# Altium schematic sheet IsoCore_Main.SchDoc
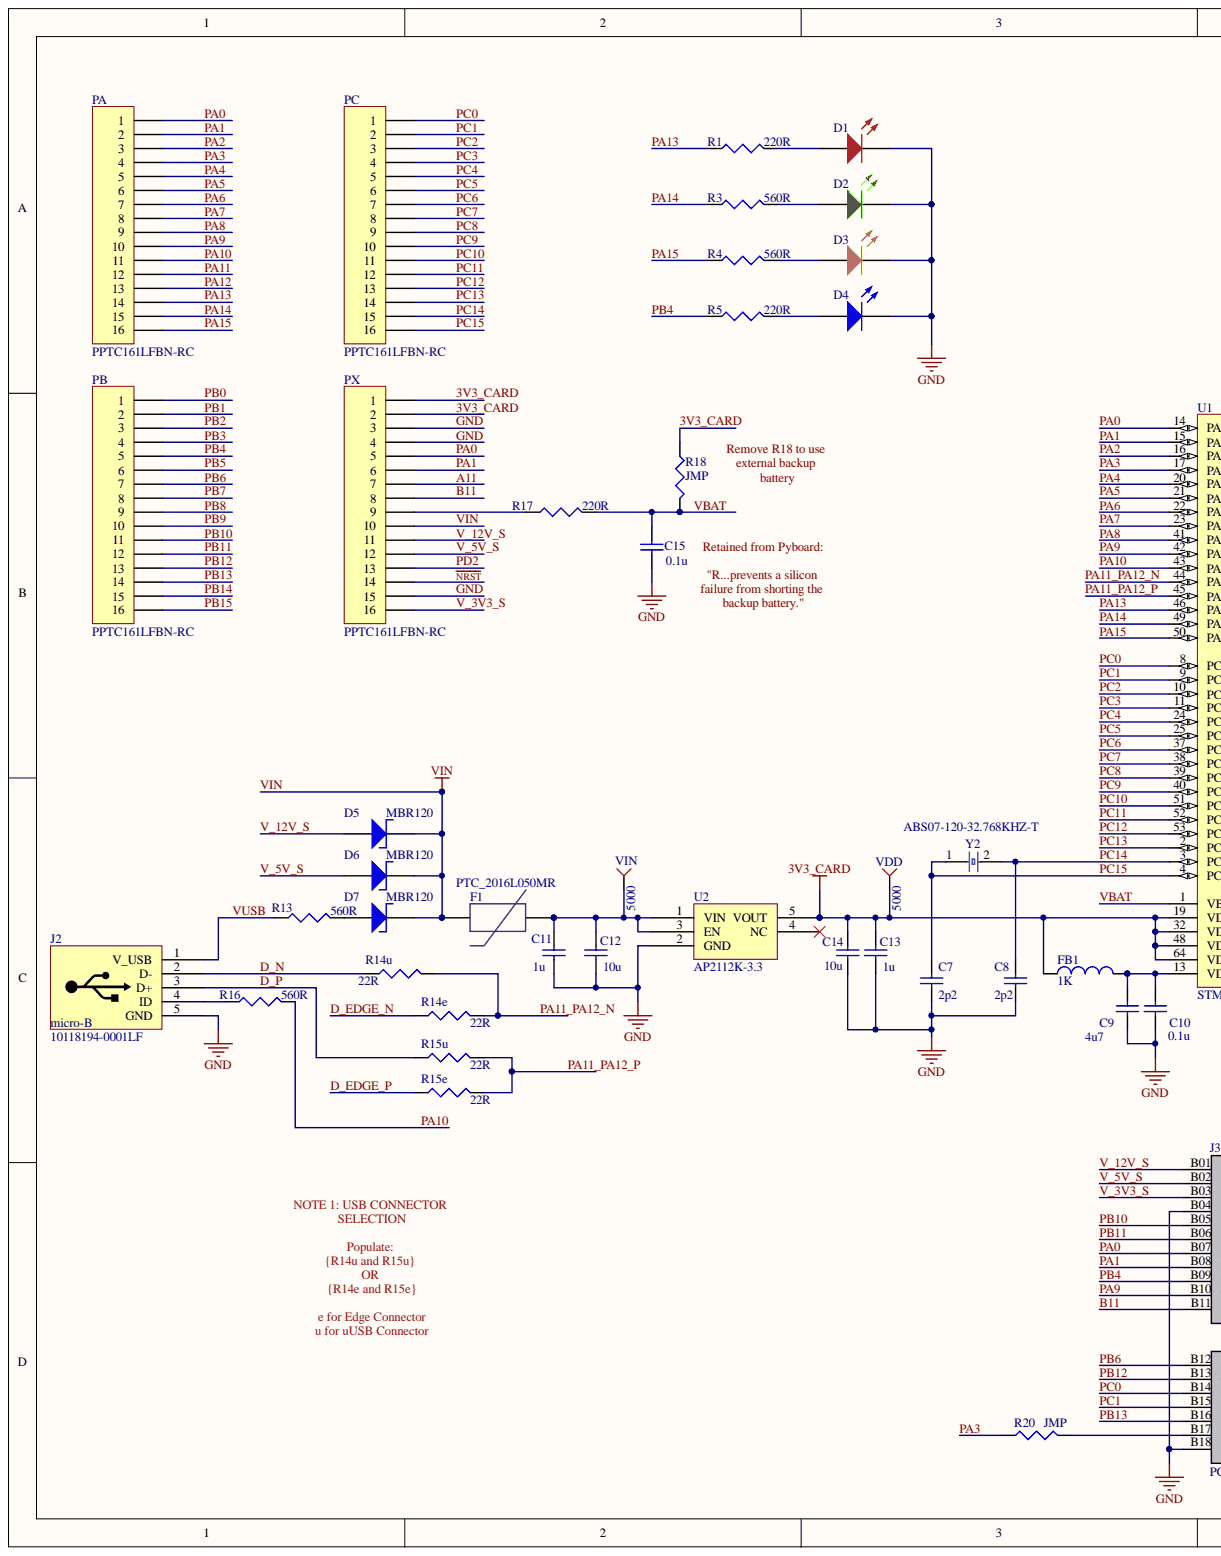
[diagram p0: IsoCore_Main.SchDoc, left]
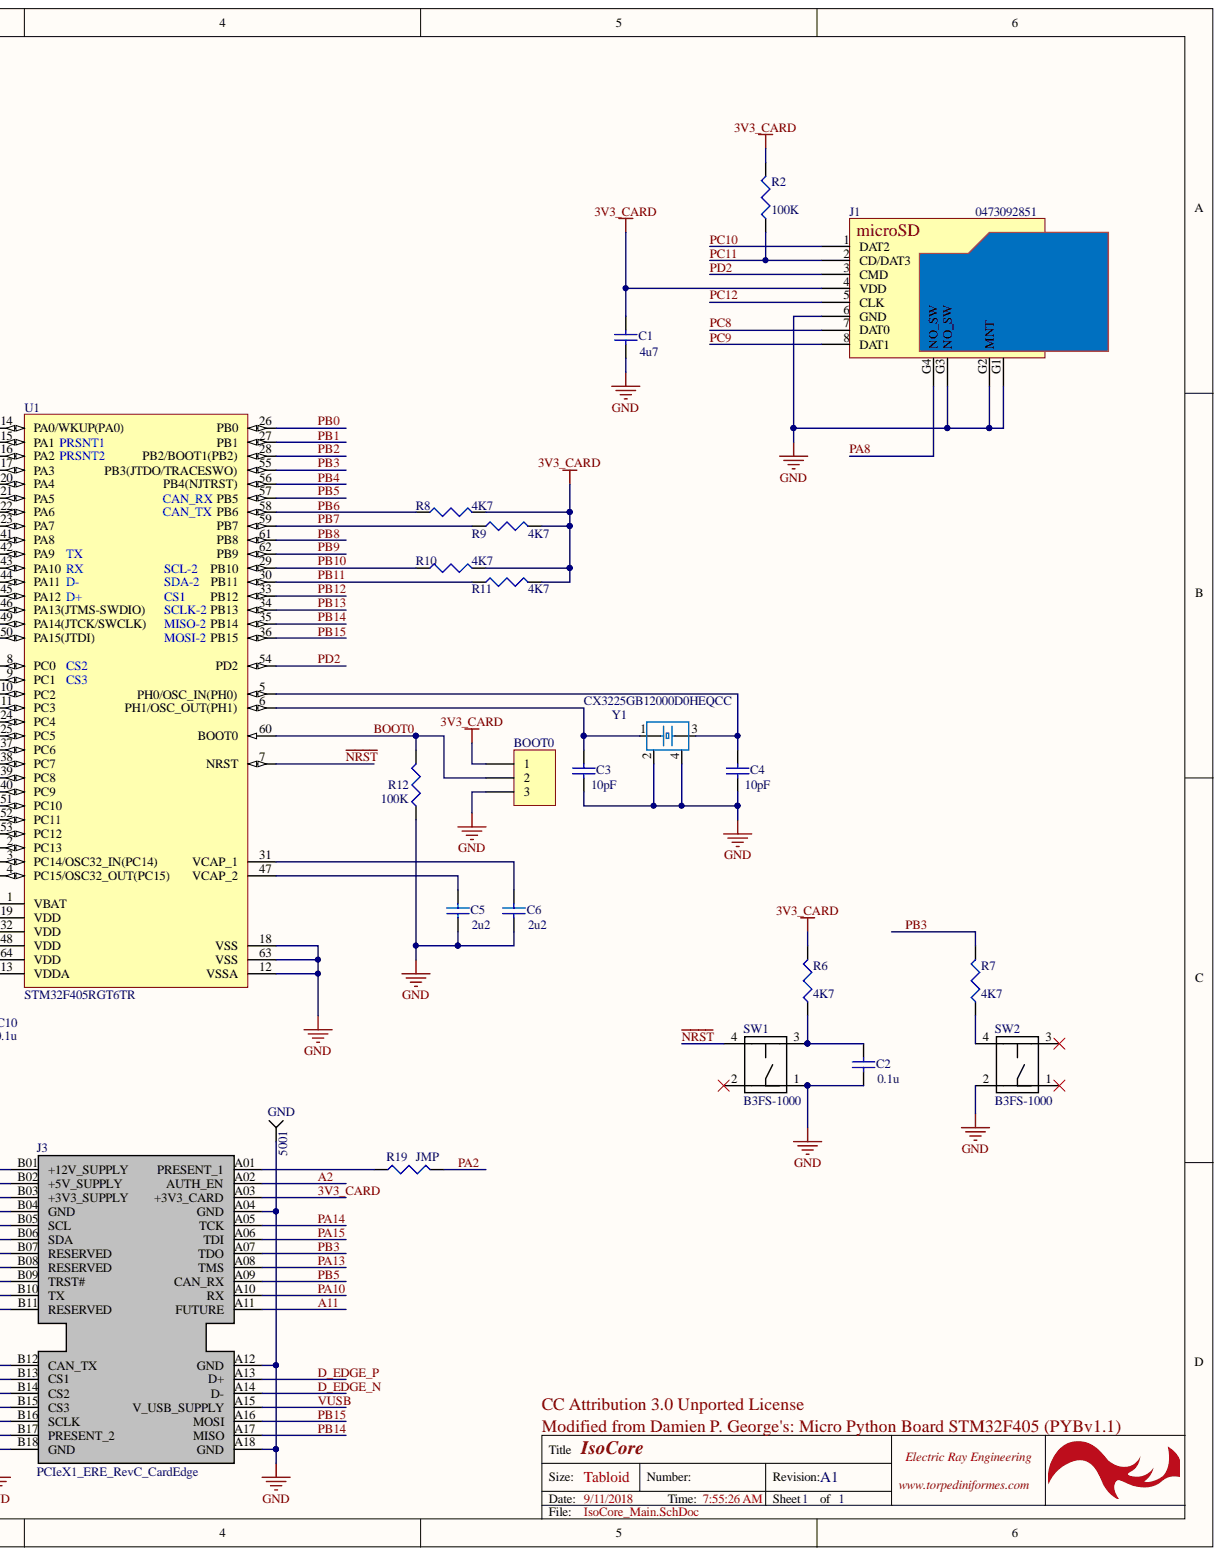
[diagram p1: IsoCore_Main.SchDoc, right]

## Parts
BOOT0  Header 3  [HDR1X3]  (value not drawn)
C1  value 4u7  [1608[0603]]  (hidden comment: Cap Semi)
C2  value 0.1u  [1608[0603]]  (hidden comment: Cap Semi)
C3  value 10pF  [1608[0603]]  (hidden comment: Cap Semi)
C4  value 10pF  [1608[0603]]  (hidden comment: Cap Semi)
C5  value 2u2  [1608[0603]]  (hidden comment: Cap Semi)
C6  value 2u2  [1608[0603]]  (hidden comment: Cap Semi)
C7  value 2p2  [1608[0603]]  (hidden comment: Cap Semi)
C8  value 2p2  [1608[0603]]  (hidden comment: Cap Semi)
C9  value 4u7  [1608[0603]]  (hidden comment: Cap Semi)
C10  value 0.1u  [1608[0603]]  (hidden comment: Cap Semi)
C11  value 1u  [1608[0603]]  (hidden comment: Cap Semi)
C12  value 10u  [C1206]  (hidden comment: Cap Semi)
C13  value 1u  [1608[0603]]  (hidden comment: Cap Semi)
C14  value 10u  [C1206]  (hidden comment: Cap Semi)
C15  value 0.1u  [1608[0603]]  (hidden comment: Cap Semi)
D1  LED_RED_SMD  [IN-S63AT_RED]  (value not drawn)
D2  LED_GREEN_0603  [IN-S63AT_GRN]  (value not drawn)
D3  LED_ORANGE_0603  [LO Q976]  (value not drawn)
D4  LED_BLUE_0603  [IN-S63AT_BLU]  (value not drawn)
D5  Diode  [SOD-123FL]  (value not drawn)
D6  Diode  [SOD-123FL]  (value not drawn)
D7  Diode  [SOD-123FL]  (value not drawn)
F1  PTC_2016L050MR  [PolyFuse_2016L]
FB1  value 1K  [J1-0603]  (hidden comment: Inductor)
GND  Manufacturer Part Number: 5001  [BLK_TP_KEYSTONE]  (hidden comment: BLK_TESTPOINT)
J1  0473092851  [0473092851]
J2  10118194-0001LF  [USB-R/A-10118194-0001LF]
J3  PCIeX1_ERE_RevC_CardEdge  [PCIeX1]
PA  PPTC161LFBN-RC  [1x16 100mil F Header]
PB  PPTC161LFBN-RC  [1x16 100mil F Header]
PC  PPTC161LFBN-RC  [1x16 100mil F Header]
PX  PPTC161LFBN-RC  [1x16 100mil F Header]
R1  value 220R  [J1-0603]  (hidden comment: Res3)
R2  value 100K  [J1-0603]  (hidden comment: Res3)
R3  value 560R  [J1-0603]  (hidden comment: Res3)
R4  value 560R  [J1-0603]  (hidden comment: Res3)
R5  value 220R  [J1-0603]  (hidden comment: Res3)
R6  value 4K7  [J1-0603]  (hidden comment: Res3)
R7  value 4K7  [J1-0603]  (hidden comment: Res3)
R8  value 4K7  [J1-0603]  (hidden comment: Res3)
R9  value 4K7  [J1-0603]  (hidden comment: Res3)
R10  value 4K7  [J1-0603]  (hidden comment: Res3)
R11  value 4K7  [J1-0603]  (hidden comment: Res3)
R12  value 100K  [J1-0603]  (hidden comment: Res3)
R13  value 560R  [J1-0603]  (hidden comment: Res3)
R14e  value 22R  [J1-0603]  (hidden comment: Res3)
R14u  value 22R  [J1-0603]  (hidden comment: Res3)
R15e  value 22R  [J1-0603]  (hidden comment: Res3)
R15u  value 22R  [J1-0603]  (hidden comment: Res3)
R16  value 560R  [J1-0603]  (hidden comment: Res3)
R17  value 220R  [J1-0603]  (hidden comment: Res3)
R18  value JMP  [J1-0603]  (hidden comment: Res3)
R19  value JMP  [J1-0603]  (hidden comment: Res3)
R20  value JMP  [J1-0603]  (hidden comment: Res3)
SW1  B3FS-1000  [B3FS-1000]
SW2  B3FS-1000  [B3FS-1000]
U1  STM32F405RGT6TR  [STM-LQFP64_N]
U2  AP2112K-3.3  [SOT25]
VDD  Manufacturer Part Number: 5000  [RED_TP_KEYSTONE]  (hidden comment: RED_TESTPOINT)
VIN  Manufacturer Part Number: 5000  [RED_TP_KEYSTONE]  (hidden comment: RED_TESTPOINT)
Y1  CX3225GB12000D0HEQCC  [XTAL32x25_BSB]
Y2  ABS07-120-32.768KHZ-T  [XTAL_32x15_BSB]

## Nets
3V3_CARD: BOOT0.1 C1.2 C13.2 C14.2 FB1.1 J1.4(VDD) J3.A03(+3V3_CARD) PX.1 PX.2 R2.2 R6.2 R8.2 R9.2 R10.2 R11.2 R18.1 U1.19(VDD) U1.32(VDD) U1.48(VDD) U1.64(VDD) U2.5(VOUT) VDD.1
A2: J3.A02(AUTH_EN)
A11: J3.A11(FUTURE) PX.7
B11: J3.B11(RESERVED) PX.8
BOOT0: BOOT0.2 R12.2 U1.60(BOOT0)
D_EDGE_N: J3.A14(D-) R14e.1
D_EDGE_P: J3.A13(D+) R15e.1
D_N: J2.2(D-) R14u.1
D_P: J2.3(D+) R15u.1
GND: BOOT0.3 C1.1 C2.1 C3.1 C4.1 C5.1 C6.1 C7.1 C8.1 C9.1 C10.1 C11.2 C12.2 C13.1 C14.1 C15.1 D1.K D2.K D3.K D4.K GND.1 J1.6(GND) J1.G1(MNT) J1.G2(MNT) J1.G3(NO_SW) J2.5(GND) J3.A04(GND) J3.A12(GND) J3.A18(GND) J3.B04(GND) J3.B18(GND) PX.3 PX.4 PX.15 R12.1 SW1.1 SW2.2 U1.12(VSSA) U1.18(VSS) U1.63(VSS) U2.2(GND) Y1.2 Y1.4
~{NRST}: PX.14 SW1.4 U1.7(NRST)
PA0: J3.B07(RESERVED) PA.1 PX.5 U1.14(PA0/WKUP(PA0))
PA1: J3.B08(RESERVED) PA.2 PX.6 U1.15(PA1_PRSNT1)
PA2: PA.3 R19.2 U1.16(PA2_PRSNT2)
PA3: PA.4 R20.1 U1.17(PA3)
PA4: PA.5 U1.20(PA4)
PA5: PA.6 U1.21(PA5)
PA6: PA.7 U1.22(PA6)
PA7: PA.8 U1.23(PA7)
PA8: J1.G4(NO_SW) PA.9 U1.41(PA8)
PA9: J3.B10(TX) PA.10 U1.42(PA9)
PA10: J3.A10(RX) PA.11 R16.2 U1.43(PA10)
PA11: PA.12
PA11_PA12_N: R14e.2 R14u.2 U1.44(PA11)
PA11_PA12_P: R15e.2 R15u.2 U1.45(PA12)
PA12: PA.13
PA13: J3.A08(TMS) PA.14 R1.1 U1.46(PA13(JTMS-SWDIO))
PA14: J3.A05(TCK) PA.15 R3.1 U1.49(PA14(JTCK/SWCLK))
PA15: J3.A06(TDI) PA.16 R4.1 U1.50(PA15(JTDI))
PB0: PB.1 U1.26(PB0)
PB1: PB.2 U1.27(PB1)
PB2: PB.3 U1.28(PB2/BOOT1(PB2))
PB3: J3.A07(TDO) PB.4 R7.2 U1.55(PB3(JTDO/TRACESWO))
PB4: J3.B09(TRST#) PB.5 R5.1 U1.56(PB4(NJTRST))
PB5: J3.A09(CAN_RX) PB.6 U1.57(CAN_RX_PB5)
PB6: J3.B12(CAN_TX) PB.7 R8.1 U1.58(CAN_TX_PB6)
PB7: PB.8 R9.1 U1.59(PB7)
PB8: PB.9 U1.61(PB8)
PB9: PB.10 U1.62(PB9)
PB10: J3.B05(SCL) PB.11 R10.1 U1.29(PB10)
PB11: J3.B06(SDA) PB.12 R11.1 U1.30(PB11)
PB12: J3.B13(CS1) PB.13 U1.33(PB12)
PB13: J3.B16(SCLK) PB.14 U1.34(SCLK-2_PB13)
PB14: J3.A17(MISO) PB.15 U1.35(MISO-2_PB14)
PB15: J3.A16(MOSI) PB.16 U1.36(MOSI-2_PB15)
PC0: J3.B14(CS2) PC.1 U1.8(PC0)
PC1: J3.B15(CS3) PC.2 U1.9(PC1)
PC2: PC.3 U1.10(PC2)
PC3: PC.4 U1.11(PC3)
PC4: PC.5 U1.24(PC4)
PC5: PC.6 U1.25(PC5)
PC6: PC.7 U1.37(PC6)
PC7: PC.8 U1.38(PC7)
PC8: J1.7(DAT0) PC.9 U1.39(PC8)
PC9: J1.8(DAT1) PC.10 U1.40(PC9)
PC10: J1.1(DAT2) PC.11 U1.51(PC10)
PC11: J1.2(CD/DAT3) PC.12 R2.1 U1.52(PC11)
PC12: J1.5(CLK) PC.13 U1.53(PC12)
PC13: PC.14 U1.2(PC13)
PC14: C8.2 PC.15 U1.3(PC14/OSC32_IN(PC14)) Y2.2
PC15: C7.2 PC.16 U1.4(PC15/OSC32_OUT(PC15)) Y2.1
PD2: J1.3(CMD) PX.13 U1.54(PD2)
V_3V3_S: J3.B03(+3V3_SUPPLY) PX.16
V_5V_S: D6.A J3.B02(+5V_SUPPLY) PX.12
V_12V_S: D5.A J3.B01(+12V_SUPPLY) PX.11
VBAT: C15.2 R17.2 R18.2 U1.1(VBAT)
VIN: D5.K D6.K D7.K F1.1 PX.10
VUSB: J2.1(V_USB) J3.A15(V_USB_SUPPLY) R13.1
NetC2_2: C2.2 R6.1 SW1.3
NetC3_2: C3.2 U1.6(PH1/OSC_OUT(PH1)) Y1.1
NetC4_2: C4.2 U1.5(PH0/OSC_IN(PH0)) Y1.3
NetC5_2: C5.2 U1.47(VCAP_2)
NetC6_2: C6.2 U1.31(VCAP_1)
NetC9_2: C9.2 C10.2 FB1.2 U1.13(VDDA)
NetC11_1: C11.1 C12.1 F1.2 U2.1(VIN) U2.3(EN) VIN.1
NetD1_A: D1.A R1.2
NetD2_A: D2.A R3.2
NetD3_A: D3.A R4.2
NetD4_A: D4.A R5.2
NetD7_A: D7.A R13.2
NetJ2_4: J2.4(ID) R16.1
NetJ3_A01: J3.A01(PRESENT_1) R19.1
NetJ3_B17: J3.B17(PRESENT_2) R20.2
NetPX_9: PX.9 R17.1
NetR7_1: R7.1 SW2.4

Not connected: SW1 2; SW2 1 3; U2 4(NC)

## Library data of the parts
BOOT0 = Header 3: Header, 3-Pin; Component Kind: Standard; LatestRevisionDate: 17-Jul-2002; LatestRevisionNote: Re-released for DXP Platform.; Library Name: Miscellaneous Connectors.IntLib; Publisher: Altium Limited
C1-C15 = Cap Semi: Capacitor (Semiconductor SIM Model); Component Kind: Standard; LatestRevisionDate: 29-May-2009; LatestRevisionNote: IPC-7351 Footprint Added.; Library Name: Miscellaneous Devices.IntLib; PackageDescription: Chip Capacitor; PackageReference: C1206; Published: 8-Jun-2000; Simulation: CAP; Supplier 1: Digi-Key; Publisher: Altium Limited
  C1 C9: Supplier Part Number 1: 490-14638-1-ND
  C2 C10 C15: Supplier Part Number 1: 490-4774-1-ND
  C3 C4: Supplier Part Number 1: 490-3570-1-ND
  C5 C6: Supplier Part Number 1: 445-13221-1-ND
  C7 C8: Supplier Part Number 1: 490-11271-1-ND
  C11 C13: Supplier Part Number 1: 490-10479-1-ND
  C12 C14: Supplier Part Number 1: 490-12425-1-ND
D1 = LED_RED_SMD: Generic LED, SMD, RED; Component Kind: Standard; Supplier 1: Digi-Key; Supplier Part Number 1: 1830-1065-1-ND; Library Name: Electric_Ray_Integrated_01SEPT2018.IntLib
D2 = LED_GREEN_0603: Generic LED, 0603, GREEN; Component Kind: Standard; Supplier 1: Digi-Key; Supplier Part Number 1: 1830-1062-1-ND; Library Name: Electric_Ray_Integrated_01SEPT2018.IntLib
D3 = LED_ORANGE_0603: Generic LED, 0603, ORANGE; Component Kind: Standard; Supplier 1: Digi-Key; Supplier Part Number 1: 475-2486-1-ND; Library Name: Electric_Ray_Integrated_01SEPT2018.IntLib
D4 = LED_BLUE_0603: Generic LED, 0603, BLUE; Component Kind: Standard; Supplier 1: Digi-Key; Supplier Part Number 1: 1830-1061-1-ND; Library Name: Electric_Ray_Integrated_01SEPT2018.IntLib
D5-D7 = MBR120: DIODE SCHOTTKY 20V 1A SOD123FL; Manufacturer: ON Semiconductor; Component Kind: Standard; Manufacturer Part Number: MBR120; Supplier 1: Digi-Key; Supplier Part Number 1: MBR120VLSFT3GOSCT-ND; Library Name: Electric_Ray_Integrated_01SEPT2018.IntLib
F1 = PTC_2016L050MR_LITTELFUSE: 600mA PTC; Manufacturer: Littelfuse Inc.; Component Kind: Standard; Manufacturer Part Number: 2016L050MR; Supplier 1: Digi-Key; Supplier Part Number 1: F4070CT-ND; Library Name: Electric_Ray_Integrated_01SEPT2018.IntLib
FB1 = Inductor: Code_IEC: 1005; Code_JEITA: 0402; Component Kind: Standard; Description: Inductor; LatestRevisionDate: 29-May-2009; LatestRevisionNote: IPC-7351 Footprint Added.; Library Name: Miscellaneous Devices.IntLib; PackageDescription: Chip Inductor; PackageReference: 0402-A; Published: 8-Jun-2000; Simulation: INDUCTOR; Supplier 1: Digi-Key; Supplier Part Number 1: 490-1015-1-ND; Publisher: Altium Limited
GND = BLK_TESTPOINT: 5001K - BLK KEYSTONE TESTPOINT; Component Kind: Standard; Manufacturer: Keystone; Library Name: Electric_Ray_Integrated_01SEPT2018.IntLib
J1 = 473092851: CONN MICRO SD CARD PUSH-PULL R/A; Manufacturer: Molex, LLC; Component Kind: Standard; Manufacturer Part Number: 0473092851; Supplier 1: Digi-Key; Supplier Part Number 1: WM6701CT-ND; Library Name: Electric_Ray_Integrated_01SEPT2018.IntLib
J2 = 10118194-0001LF: USB uB R/A Board Edge Connector; Manufacturer: Amphenol FCI; Component Kind: Standard; Manufacturer Part Number: 10118194-0001LF; Supplier 1: Digi-Key; Supplier Part Number 1: 609-4618-1-ND; Library Name: Electric_Ray_Integrated_01SEPT2018.IntLib
J3 = PCIeX1_ElectricRay_RevC_CardEdge: Card Edge Fingers, 38pin, Dual Sided, PCIeX1 Physical Layout; Component Kind: Standard; Library Name: ERI_01SEPT2018.SchLib
PA PB PC PX = PPTC161LFBN-RC: CONN HEADER FEMALE 16POS.1" TIN; Manufacturer: Sullins Connector Solutions; Component Kind: Standard; Manufacturer Part Number: PPTC161LFBN-RC; Library Name: Electric_Ray_Integrated_01SEPT2018.IntLib
R1-R13 R14e R14u R15e R15u R16-R20 = Res3: Resistor; Component Kind: Standard; LatestRevisionDate: 29-May-2009; LatestRevisionNote: IPC-7351 Footprint Added.; Library Name: Miscellaneous Devices.IntLib; PackageDescription: Chip Resistor; PackageReference: J1-0603; Published: 8-Jun-2000; Simulation: RESISTOR; Supplier 1: Digi-Key; Publisher: Altium Limited
  R1 R5 R17: Supplier Part Number 1: 311-220HRCT-ND
  R2 R12: Supplier Part Number 1: 311-100KLDCT-ND
  R3 R4 R13 R16: Supplier Part Number 1: 311-560GRCT-ND
  R6-R11: Supplier Part Number 1: 311-4.70KHRCT-ND
  R14e R14u R15e R15u: Supplier Part Number 1: 311-22GRCT-ND
  R18-R20: Supplier Part Number 1: 311-0.0GRCT-ND
SW1 SW2 = B3FS-1000: SWITCH TACTILE SPST-NO 0.05A 24V; Manufacturer: Omron; Component Kind: Standard; Manufacturer Part Number: B3FS-1000; Supplier 1: Digi-Key; Supplier Part Number 1: Z5199-ND; Library Name: Electric_Ray_Integrated_01SEPT2018.IntLib
U1 = CMP-0243-00156-1: ARM Cortex-M4 32-bit MCU+FPU, 1024 KB Flash, 192 KB Internal RAM, 51 I/Os, 64-Pin LQFP, -40 to 85 degC, Tape and Reel; D/A Converter: 2; FLASH Size (Prog) (kB): 1024; I/Os (High Current): 51; Internal RAM Size (kB): 192; Operating Frequency(F) (Processor speed) (MHz): 168; Supply Voltage(Vcc) min (V): 1.8; Component Kind: Standard; ComponentLink1Description: Manufacturer URL; ComponentLink1URL: http://www.st.com/; ComponentLink2Description: Datasheet; ComponentLink2URL: http://www.st.com/st-web-ui/static/active/en/resource/technical/document/datasheet/DM00037051.pdf; Core: ARM Cortex-M4; DatasheetVersion: Rev. 6; Library Name: Altium Content Vault; Mounting Technology: Surface Mount; Package Description: LQFP64; Package Reference: 64-Pin Low-profile Quad Flat Package, Body 10 x 10 mm, Pitch 0.5 mm; Packing: Tape and Reel; Supplier 1: Digi-Key; Supplier Part Number 1: 497-17432-1-ND
U2 = AP2112K-3.3: IC REG LINEAR 3.3V 600MA SOT25; Manufacturer: Diodes Incorporated; Component Kind: Standard; Manufacturer Part Number: AP2112K-3.3; Supplier 1: Digi-Key; Supplier Part Number 1: AP2112K-3.3TRG1DICT-ND; Library Name: Electric_Ray_Integrated_01SEPT2018.IntLib
VDD VIN = RED_TESTPOINT: 5000K - RED KEYSTONE TESTPOINT; Component Kind: Standard; Manufacturer: Keystone; Library Name: Electric_Ray_Integrated_01SEPT2018.IntLib
Y1 = CX3225GB12000D0HEQCC: CRYSTAL 12.0000MHZ 8PF SMD; Manufacturer: AVX / Kyocera; Component Kind: Standard; Manufacturer Part Number: CX3225GB12000D0HEQCC; Supplier 1: Digi-Key; Supplier Part Number 1: 1253-1166-1-ND; Library Name: Electric_Ray_Integrated_01SEPT2018.IntLib
Y2 = ABS07-120-32.768KHZ-T: CRYSTAL 32.7680KHZ 6PF SMD; Manufacturer: Abracon LLC; Component Kind: Standard; Manufacturer Part Number: ABS07-120-32.768KHZ-T; Supplier 1: Digi-Key; Supplier Part Number 1: 535-11937-1-ND; Library Name: Electric_Ray_Integrated_01SEPT2018.IntLib
